# Altium schematic sheet Slave_V2_1.SchDoc(Slave_V2_1)
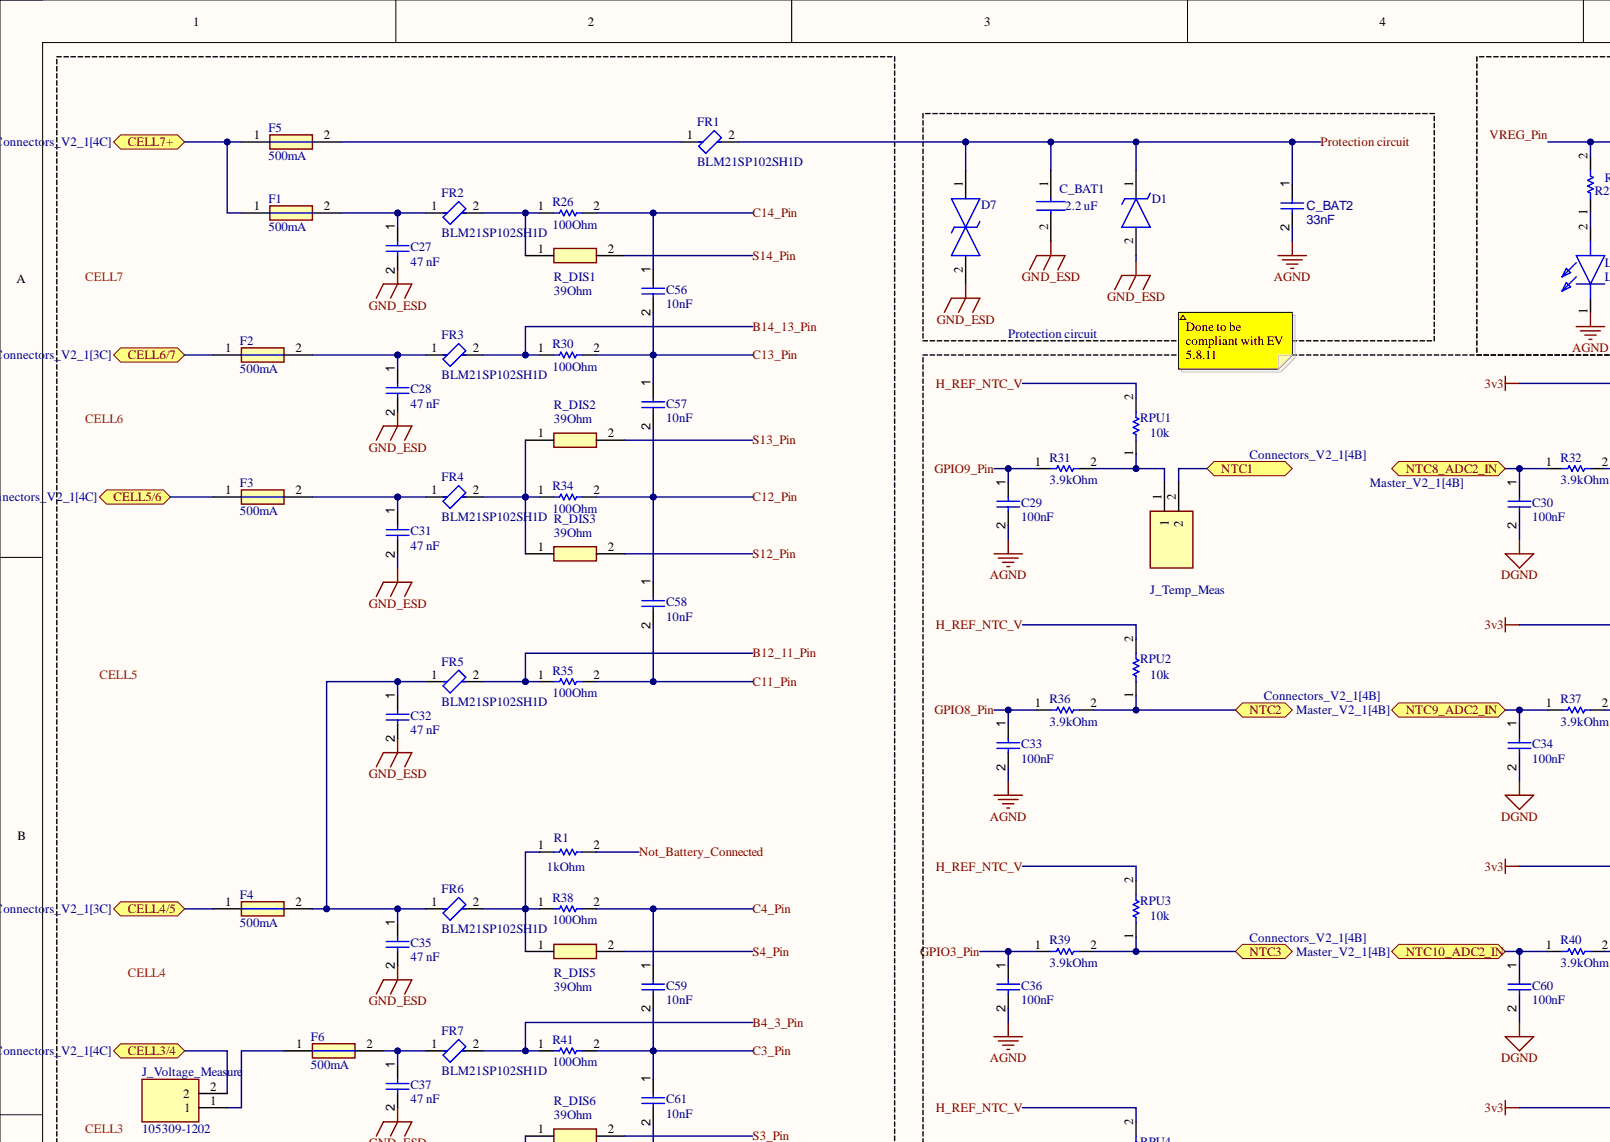
[diagram p0: Slave_V2_1.SchDoc, top left]
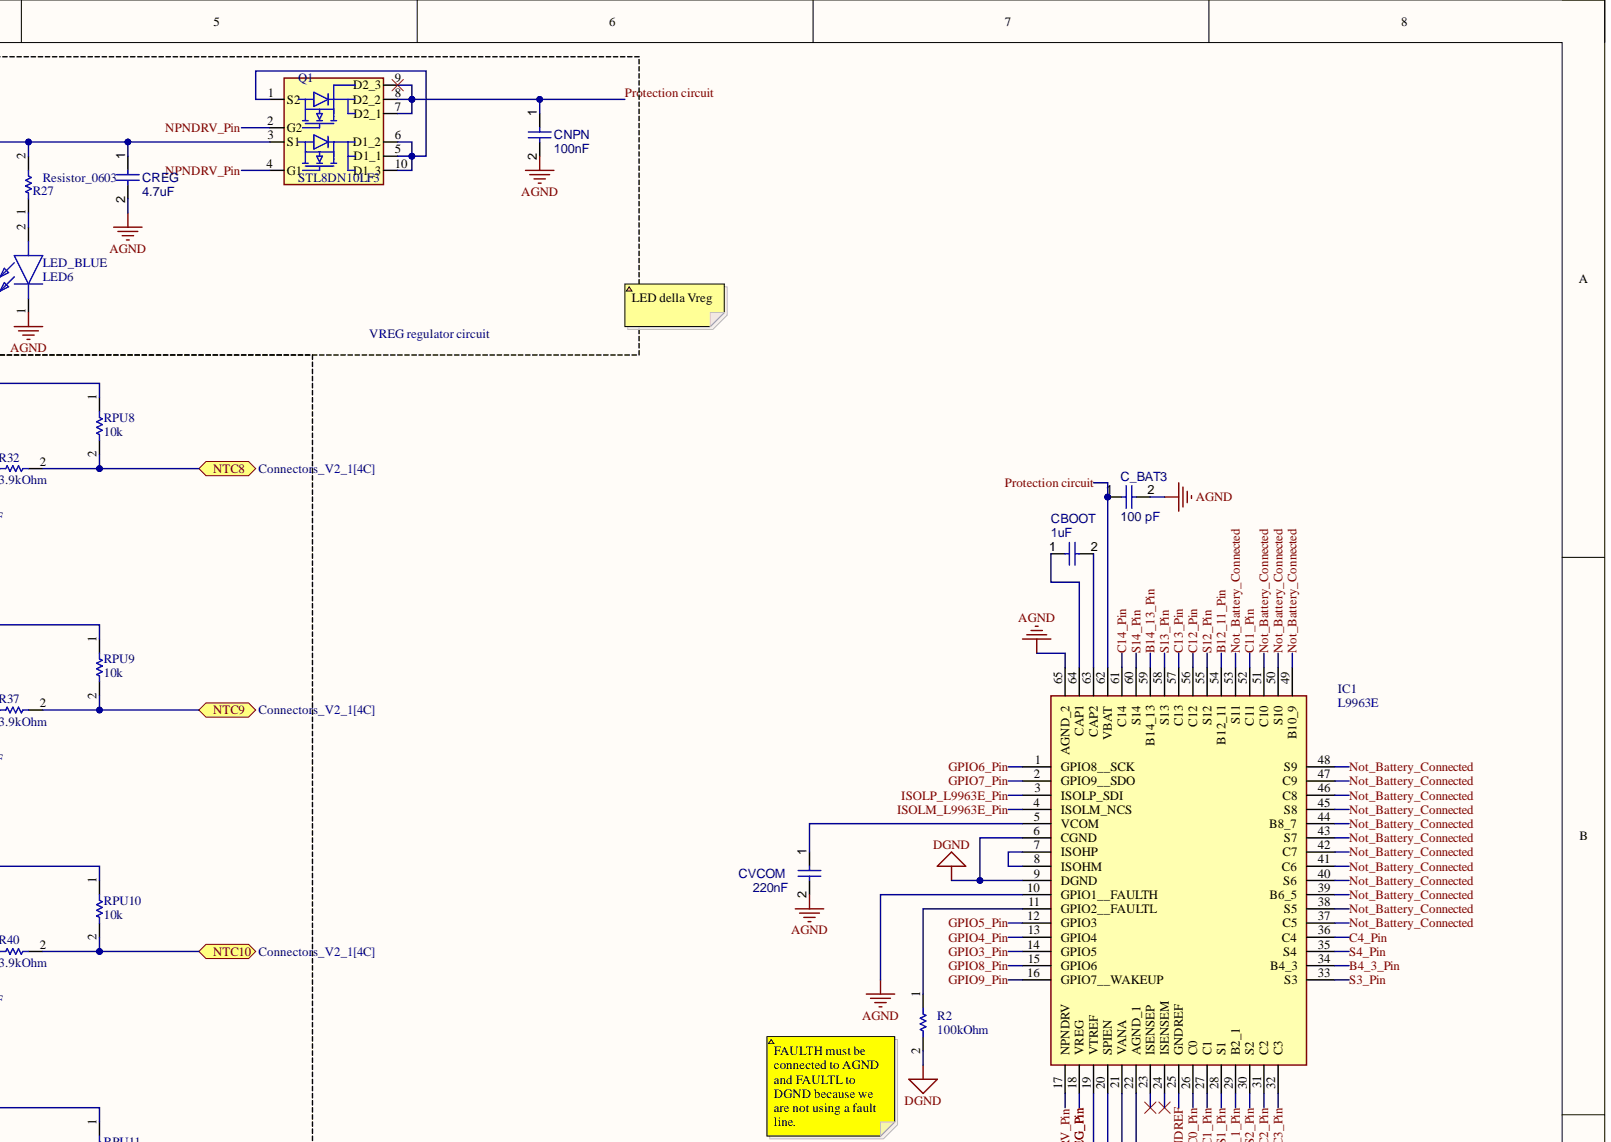
[diagram p1: Slave_V2_1.SchDoc, top right]
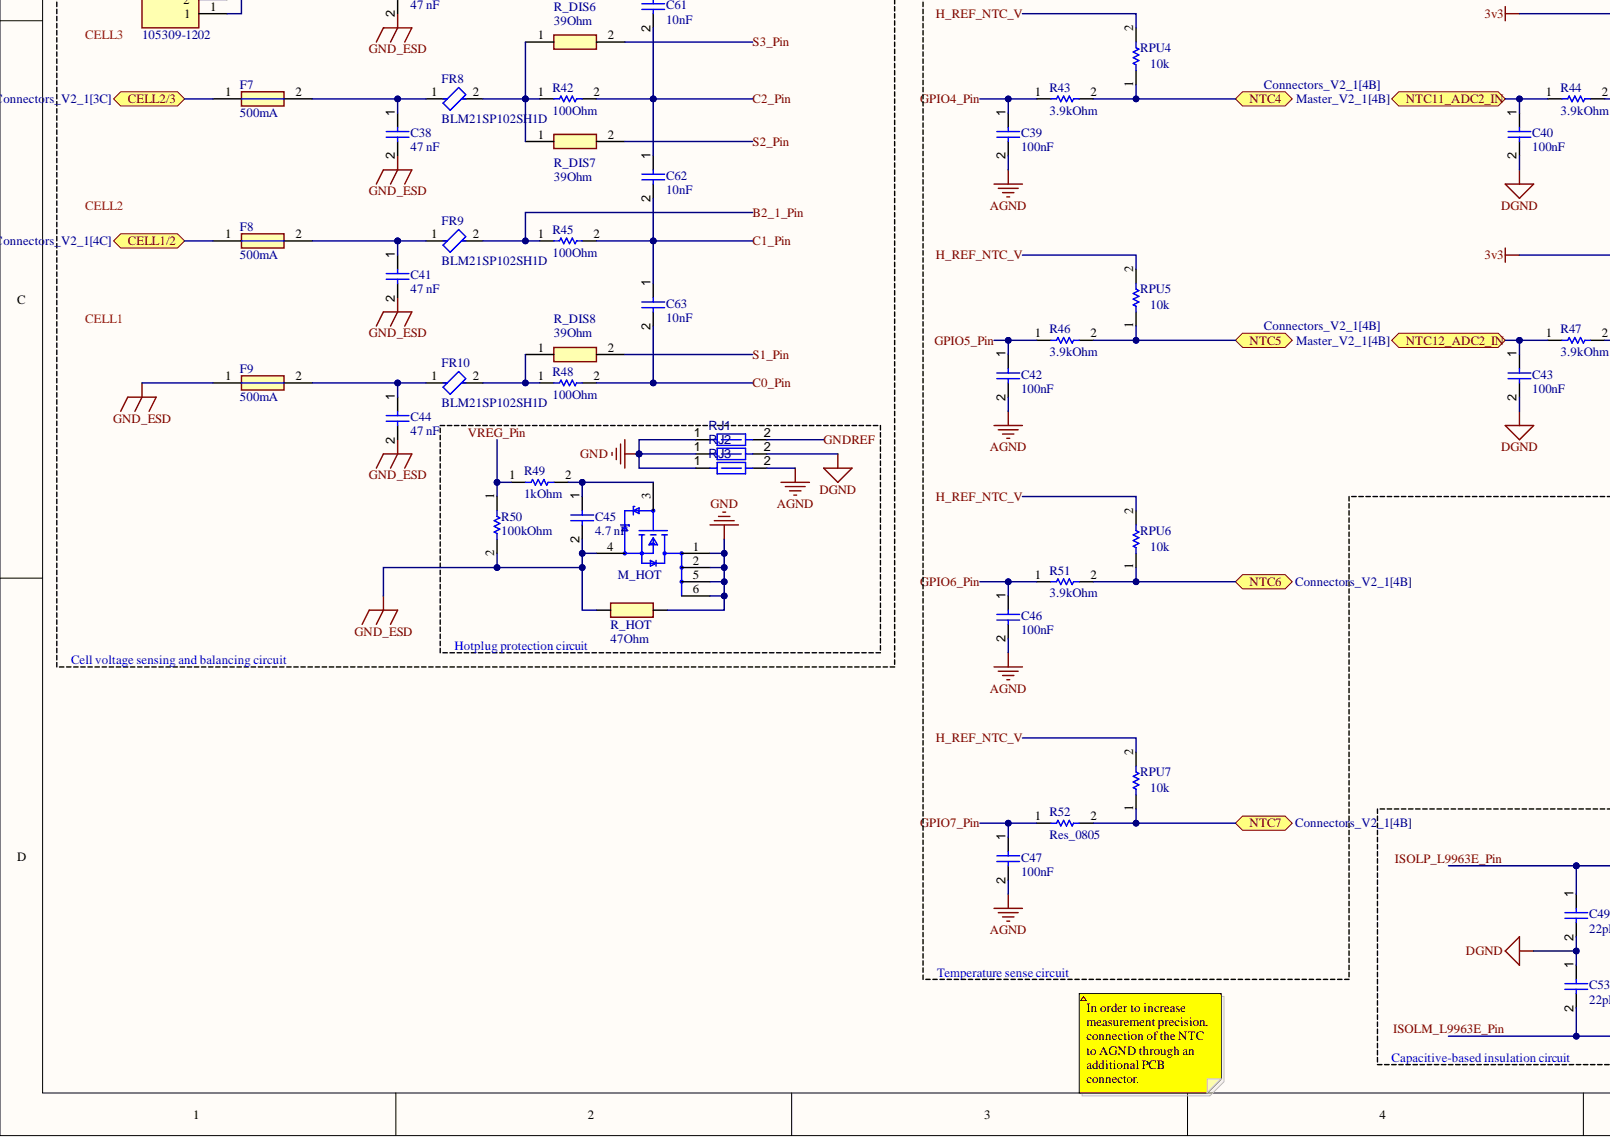
[diagram p2: Slave_V2_1.SchDoc, bottom left]
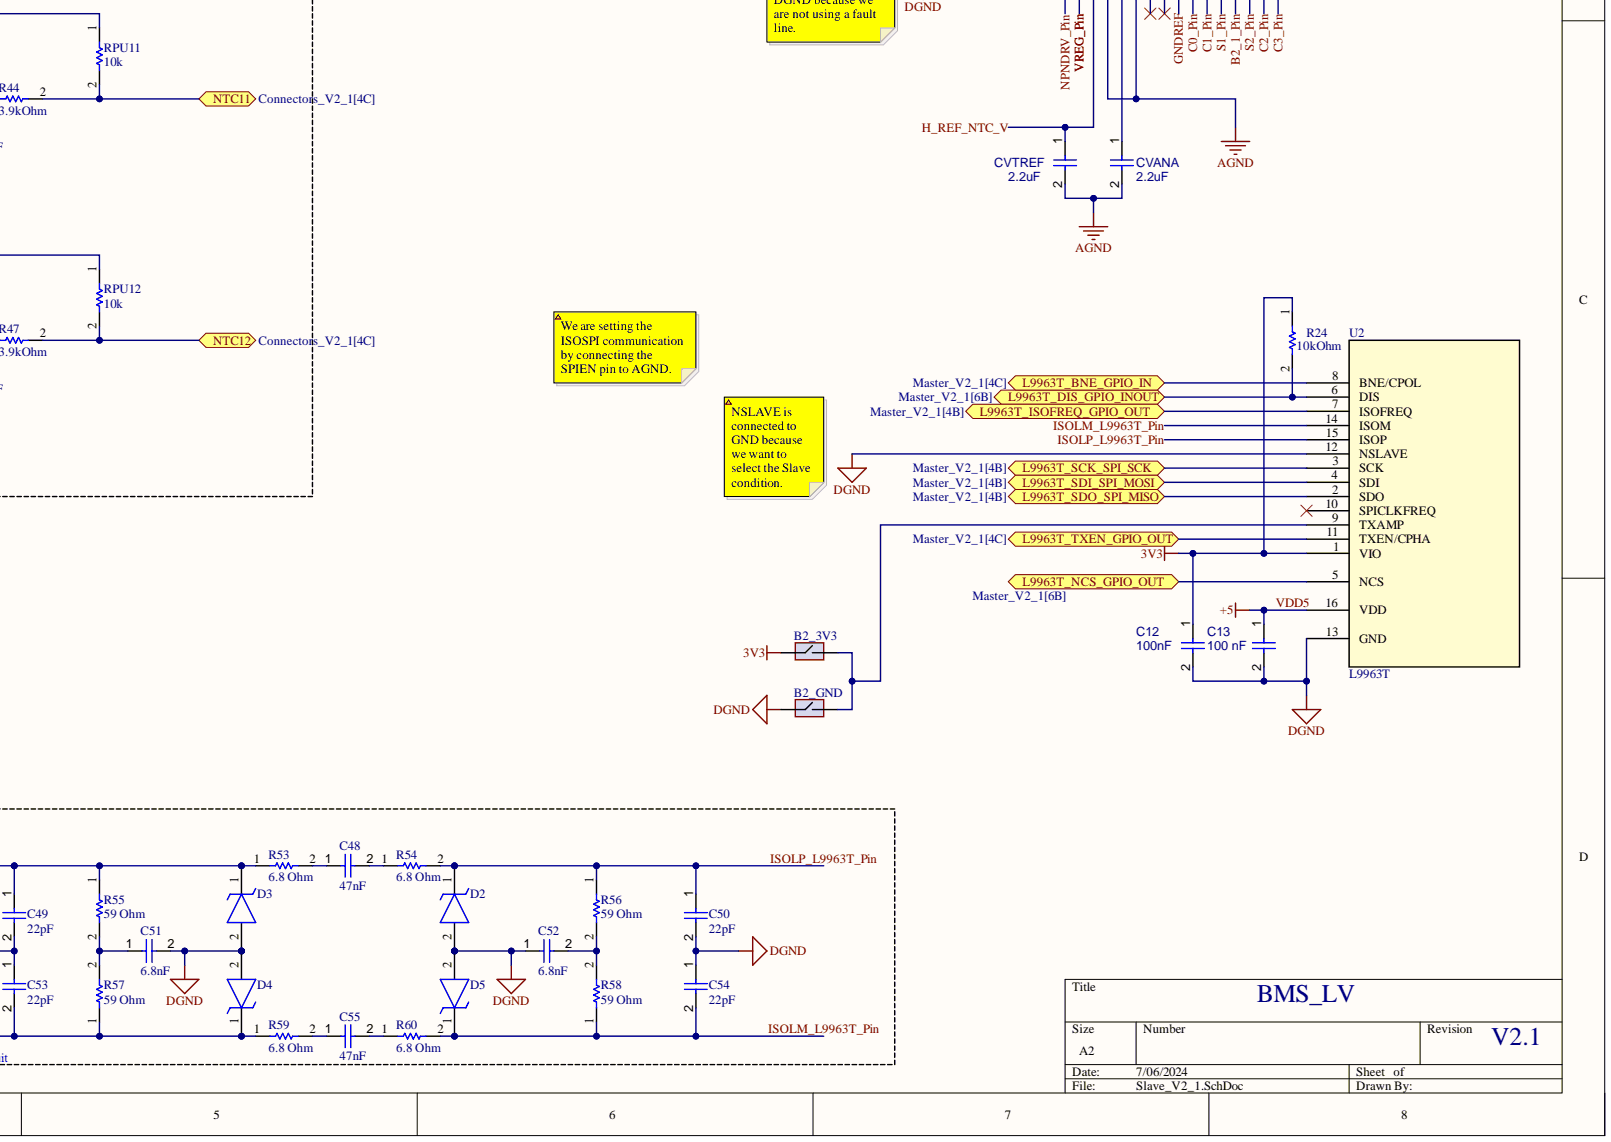
[diagram p3: Slave_V2_1.SchDoc, bottom right]

## Parts
B2_3V3  SolderBridge_0805  (value not drawn)  (no BOM)
B2_GND  SolderBridge_0805  (value not drawn)  (no BOM)
C12  100nF
C13  100 nF
C27  47 nF
C28  47 nF
C29  100nF
C30  100nF
C31  47 nF
C32  47 nF
C33  100nF
C34  100nF
C35  47 nF
C36  100nF
C37  47 nF
C38  47 nF
C39  100nF
C40  100nF
C41  47 nF
C42  100nF
C43  100nF
C44  47 nF
C45  4.7 nF
C46  100nF
C47  100nF
C48  47nF
C49  22pF
C50  22pF
C51  6.8nF
C52  6.8nF
C53  22pF
C54  22pF
C55  47nF
C56  10nF
C57  10nF
C58  10nF
C59  10nF
C60  100nF
C61  10nF
C62  10nF
C63  10nF
C_BAT1  2.2 uF
C_BAT2  33nF
C_BAT3  100 pF
CBOOT  1uF
CNPN  100nF
CREG  4.7uF
CVANA  2.2uF
CVCOM  220nF
CVTREF  2.2uF
D1  SMA6T68AY  (value not drawn)
D2  SZESD8351P2T5G  (value not drawn)
D3  SZESD8351P2T5G  (value not drawn)
D4  SZESD8351P2T5G  (value not drawn)
D5  SZESD8351P2T5G  (value not drawn)
D7  SMAJ30CA-TR  (value not drawn)
F1  500mA
F2  500mA
F3  500mA
F4  500mA
F5  500mA
F6  500mA
F7  500mA
F8  500mA
F9  500mA
FR1  BLM21SP102SH1D
FR2  BLM21SP102SH1D
FR3  BLM21SP102SH1D
FR4  BLM21SP102SH1D
FR5  BLM21SP102SH1D
FR6  BLM21SP102SH1D
FR7  BLM21SP102SH1D
FR8  BLM21SP102SH1D
FR9  BLM21SP102SH1D
FR10  BLM21SP102SH1D
IC1  L9963E
J_Temp_Meas  105309-1202
J_Voltage_Measure  105309-1202
LED6  LED_BLUE
M_HOT  PMN280ENEAX  (value not drawn)
Q1  STL8DN10LF3
R1  1kOhm
R2  100kOhm
R24  10kOhm
R26  100Ohm
R27  Resistor_0603
R30  100Ohm
R31  3.9kOhm
R32  3.9kOhm
R34  100Ohm
R35  100Ohm
R36  3.9kOhm
R37  3.9kOhm
R38  100Ohm
R39  3.9kOhm
R40  3.9kOhm
R41  100Ohm
R42  100Ohm
R43  3.9kOhm
R44  3.9kOhm
R45  100Ohm
R46  3.9kOhm
R47  3.9kOhm
R48  100Ohm
R49  1kOhm
R50  100kOhm
R51  3.9kOhm
R52  Res_0805
R53  6.8 Ohm
R54  6.8 Ohm
R55  59 Ohm
R56  59 Ohm
R57  59 Ohm
R58  59 Ohm
R59  6.8 Ohm
R60  6.8 Ohm
R_DIS1  39Ohm
R_DIS2  39Ohm
R_DIS3  39Ohm
R_DIS5  39Ohm
R_DIS6  39Ohm
R_DIS7  39Ohm
R_DIS8  39Ohm
R_HOT  47Ohm
RJ1  Net Tie 12x8mil  (value not drawn)
RJ2  Net Tie 12x8mil  (value not drawn)
RJ3  Net Tie 12x8mil  (value not drawn)
RPU1  10k
RPU2  10k
RPU3  10k
RPU4  10k
RPU5  10k
RPU6  10k
RPU7  10k
RPU8  10k
RPU9  10k
RPU10  10k
RPU11  10k
RPU12  10k
U2  L9963T

## Ports
CELL1/2, CELL2/3, CELL3/4, CELL4/5, CELL5/6, CELL6/7, CELL7+, L9963T_BNE_GPIO_IN, L9963T_DIS_GPIO_INOUT, L9963T_ISOFREQ_GPIO_OUT, L9963T_NCS_GPIO_OUT, L9963T_SCK_SPI_SCK, L9963T_SDI_SPI_MOSI, L9963T_SDO_SPI_MISO, L9963T_TXEN_GPIO_OUT, NTC1, NTC2, NTC3, NTC4, NTC5, NTC6, NTC7, NTC8, NTC8_ADC2_IN, NTC9, NTC9_ADC2_IN, NTC10, NTC10_ADC2_IN, NTC11, NTC11_ADC2_IN, NTC12, NTC12_ADC2_IN

## Nets
3v3: B2_3V3.1 C12.1 R24.1 RPU8.1 RPU9.1 RPU10.1 RPU11.1 RPU12.1 U2.1(VIO)
AGND: C29.2 C33.2 C36.2 C39.2 C42.2 C46.2 C47.2 C_BAT2.2 C_BAT3.2 CNPN.2 CREG.2 CVANA.2 CVCOM.2 CVTREF.2 IC1.10(GPIO1__FAULTH) IC1.20(SPIEN) IC1.22(AGND_1) IC1.65(AGND_2) LED6.1 RJ3.2
DGND: B2_GND.1 C12.2 C13.2 C30.2 C34.2 C40.2 C43.2 C49.2 C50.2 C51.2 C52.1 C53.1 C54.1 C60.2 D2.2 D3.2 D4.2 D5.2 IC1.6(CGND) IC1.9(DGND) R2.2 RJ2.2 U2.12(NSLAVE) U2.13(GND)
GND: M_HOT.1 M_HOT.2 M_HOT.5 M_HOT.6 R_HOT.2 RJ1.1 RJ2.1 RJ3.1
GND_ESD: C27.2 C28.2 C31.2 C32.2 C35.2 C37.2 C38.2 C41.2 C44.2 C45.2 C_BAT1.2 D1.2 D7.2 F9.1 M_HOT.4 R50.2 R_HOT.1
B2_1_Pin: FR9.2 IC1.29(B2_1) R45.1
B4_3_Pin: FR7.2 IC1.34(B4_3) R41.1
B12_11_Pin: FR5.2 IC1.54(B12_11) R35.1
B14_13_Pin: FR3.2 IC1.59(B14_13) R30.1
C0_Pin: C63.2 IC1.26(C0) R48.2
C1_Pin: C62.2 C63.1 IC1.27(C1) R45.2
C2_Pin: C61.2 C62.1 IC1.31(C2) R42.2
C3_Pin: C59.2 C61.1 IC1.32(C3) R41.2
C4_Pin: C59.1 IC1.36(C4) R38.2
C11_Pin: C58.2 IC1.52(C11) R35.2
C12_Pin: C57.2 C58.1 IC1.56(C12) R34.2
C13_Pin: C56.2 C57.1 IC1.57(C13) R30.2
C14_Pin: C56.1 IC1.61(C14) R26.2
CELL1/2: F8.1 ; port CELL1/2
CELL2/3: F7.1 ; port CELL2/3
CELL3/4: J_Voltage_Measure.2 ; port CELL3/4
CELL4/5: F4.1 ; port CELL4/5
CELL5/6: F3.1 ; port CELL5/6
CELL6/7: F2.1 ; port CELL6/7
CELL7+: F1.1 F5.1 ; port CELL7+
GNDREF: IC1.25(GNDREF) RJ1.2
GPIO3_Pin: C36.1 IC1.14(GPIO5) R39.1
GPIO4_Pin: C39.1 IC1.13(GPIO4) R43.1
GPIO5_Pin: C42.1 IC1.12(GPIO3) R46.1
GPIO6_Pin: C46.1 IC1.1(GPIO8__SCK) R51.1
GPIO7_Pin: C47.1 IC1.2(GPIO9__SDO) R52.1
GPIO8_Pin: C33.1 IC1.15(GPIO6) R36.1
GPIO9_Pin: C29.1 IC1.16(GPIO7__WAKEUP) R31.1
H_REF_NTC_V: CVTREF.1 IC1.19(VTREF) RPU1.2 RPU2.2 RPU3.2 RPU4.2 RPU5.2 RPU6.2 RPU7.2
ISOLM_L9963E_Pin: C53.2 D4.1 IC1.4(ISOLM_NCS) R57.1 R59.1
ISOLM_L9963T_Pin: C54.2 D5.1 R58.1 R60.2 U2.14(ISOM)
ISOLP_L9963E_Pin: C49.1 D3.1 IC1.3(ISOLP_SDI) R53.1 R55.1
ISOLP_L9963T_Pin: C50.1 D2.1 R54.2 R56.1 U2.15(ISOP)
L9963T_BNE_GPIO_IN: U2.8(BNE/CPOL) ; port L9963T_BNE_GPIO_IN
L9963T_DIS_GPIO_INOUT: R24.2 U2.6(DIS) ; port L9963T_DIS_GPIO_INOUT
L9963T_ISOFREQ_GPIO_OUT: U2.7(ISOFREQ) ; port L9963T_ISOFREQ_GPIO_OUT
L9963T_NCS_GPIO_OUT: U2.5(NCS) ; port L9963T_NCS_GPIO_OUT
L9963T_SCK_SPI_SCK: U2.3(SCK) ; port L9963T_SCK_SPI_SCK
L9963T_SDI_SPI_MOSI: U2.4(SDI) ; port L9963T_SDI_SPI_MOSI
L9963T_SDO_SPI_MISO: U2.2(SDO) ; port L9963T_SDO_SPI_MISO
L9963T_TXEN_GPIO_OUT: U2.11(TXEN/CPHA) ; port L9963T_TXEN_GPIO_OUT
Not_Battery_Connected: IC1.37(C5) IC1.38(S5) IC1.39(B6_5) IC1.40(S6) IC1.41(C6) IC1.42(C7) IC1.43(S7) IC1.44(B8_7) IC1.45(S8) IC1.46(C8) IC1.47(C9) IC1.48(S9) IC1.49(B10_9) IC1.50(S10) IC1.51(C10) IC1.53(S11) R1.2
NPNDRV_Pin: IC1.17(NPNDRV) Q1.2(G2) Q1.4(G1)
NTC1: J_Temp_Meas.2 ; port NTC1
NTC2: R36.2 RPU2.1 ; port NTC2
NTC3: R39.2 RPU3.1 ; port NTC3
NTC4: R43.2 RPU4.1 ; port NTC4
NTC5: R46.2 RPU5.1 ; port NTC5
NTC6: R51.2 RPU6.1 ; port NTC6
NTC7: R52.2 RPU7.1 ; port NTC7
NTC8: R32.2 RPU8.2 ; port NTC8
NTC8_ADC2_IN: C30.1 R32.1 ; port NTC8_ADC2_IN
NTC9: R37.2 RPU9.2 ; port NTC9
NTC9_ADC2_IN: C34.1 R37.1 ; port NTC9_ADC2_IN
NTC10: R40.2 RPU10.2 ; port NTC10
NTC10_ADC2_IN: C60.1 R40.1 ; port NTC10_ADC2_IN
NTC11: R44.2 RPU11.2 ; port NTC11
NTC11_ADC2_IN: C40.1 R44.1 ; port NTC11_ADC2_IN
NTC12: R47.2 RPU12.2 ; port NTC12
NTC12_ADC2_IN: C43.1 R47.1 ; port NTC12_ADC2_IN
Protection circuit: C_BAT1.1 C_BAT2.1 C_BAT3.1 CNPN.1 D1.1 D7.1 FR1.2 IC1.62(VBAT) Q1.7(D2_1) Q1.8(D2_2) Q1.9(D2_3)
S1_Pin: IC1.28(S1) R_DIS8.2
S2_Pin: IC1.30(S2) R_DIS7.2
S3_Pin: IC1.33(S3) R_DIS6.2
S4_Pin: IC1.35(S4) R_DIS5.2
S12_Pin: IC1.55(S12) R_DIS3.2
S13_Pin: IC1.58(S13) R_DIS2.2
S14_Pin: IC1.60(S14) R_DIS1.2
VDD5: C13.1 U2.16(VDD)
VREG_Pin: CREG.1 IC1.18(VREG) Q1.3(S1) R27.2 R49.1 R50.1
NetB2_3V3_2: B2_3V3.2 B2_GND.2 U2.9(TXAMP)
NetC27_1: C27.1 F1.2 FR2.1
NetC28_1: C28.1 F2.2 FR3.1
NetC31_1: C31.1 F3.2 FR4.1
NetC32_1: C32.1 C35.1 F4.2 FR5.1 FR6.1
NetC37_1: C37.1 F6.2 FR7.1
NetC38_1: C38.1 F7.2 FR8.1
NetC41_1: C41.1 F8.2 FR9.1
NetC44_1: C44.1 F9.2 FR10.1
NetC45_1: C45.1 M_HOT.3 R49.2
NetC48_1: C48.1 R53.2
NetC48_2: C48.2 R54.1
NetC51_1: C51.1 R55.2 R57.2
NetC52_2: C52.2 R56.2 R58.2
NetC55_1: C55.1 R59.2
NetC55_2: C55.2 R60.1
NetCBOOT_1: CBOOT.1 IC1.64(CAP1)
NetCBOOT_2: CBOOT.2 IC1.63(CAP2)
NetCVANA_1: CVANA.1 IC1.21(VANA)
NetCVCOM_1: CVCOM.1 IC1.5(VCOM)
NetF5_2: F5.2 FR1.1
NetF6_1: F6.1 J_Voltage_Measure.1
NetFR2_2: FR2.2 R26.1 R_DIS1.1
NetFR4_2: FR4.2 R34.1 R_DIS2.1 R_DIS3.1
NetFR6_2: FR6.2 R1.1 R38.1 R_DIS5.1
NetFR8_2: FR8.2 R42.1 R_DIS6.1 R_DIS7.1
NetFR10_2: FR10.2 R48.1 R_DIS8.1
NetIC1_7: IC1.7(ISOHP) IC1.8(ISOHM)
NetIC1_11: IC1.11(GPIO2__FAULTL) R2.1
NetJ_Temp_Meas_1: J_Temp_Meas.1 R31.2 RPU1.1
NetLED6_2: LED6.2 R27.1
NetQ1_1: Q1.1(S2) Q1.5(D1_1) Q1.6(D1_2) Q1.10(D1_3)

Not connected: IC1 23(ISENSEP) 24(ISENSEM); U2 10(SPICLKFREQ)

## Library data of the parts
B2_3V3 B2_GND = CMP-00006-5: 0805 Solder Bridge; Library Name: EMEA- Squadra Corse PoliTO-  Politecnico di Torino
C12 C13 = CMP-001-00085-2: VJ0805Y104MXATW1BC; Tech: SMD; Component Kind: Standard; ComponentLink1Description: Website; Package: 0805; Library Name: EMEA- Squadra Corse PoliTO-  Politecnico di Torino
C27 C28 C31 C32 C35 C37 C38 C41 C44 C48 C55 = CMP-00009-1: VJ0805Y473KXBAT; Component Kind: Standard; Library Name: EMEA- Squadra Corse PoliTO-  Politecnico di Torino
C29 C30 C33 C34 C36 C39 C40 C42 C43 C46 C47 C60 = CMP-00009-1: VJ0805Y104KBABE31; Component Kind: Standard; Library Name: EMEA- Squadra Corse PoliTO-  Politecnico di Torino
C45 = CMP-00009-1: VJ0805Y472JXJCW1BC; Component Kind: Standard; Library Name: EMEA- Squadra Corse PoliTO-  Politecnico di Torino
C49 C50 C53 C54 = CMP-00009-1: VJ0805A220JXBAC; Component Kind: Standard; Library Name: EMEA- Squadra Corse PoliTO-  Politecnico di Torino
C51 C52 = CMP-00009-1: VJ0805Y682KXAAC; Component Kind: Standard; Library Name: EMEA- Squadra Corse PoliTO-  Politecnico di Torino
C56-C59 C61-C63 = CMP-00009-1: VJ0805H103KXBBC31; Component Kind: Standard; Library Name: EMEA- Squadra Corse PoliTO-  Politecnico di Torino
C_BAT1 = CMP-002-000022-1: C3225X7R2A225K230AM; Manufacturer_Name: TDK; Arrow Part Number: C3225X7R2A225K230AM; Arrow Price/Stock: https://www.arrow.com/en/products/c3225x7r2a225k230am/tdk?region=nac; Component Kind: Standard; Datasheet Link: https://product.tdk.com/system/files/dam/doc/product/capacitor/ceramic/mlcc/catalog/mlcc_commercial_openmode_en.pdf; Design Item ID: C3225X7R2A225K230AM; Height: 2.8mm; Manufacturer_Part_Number: C3225X7R2A225K230AM; Mouser Part Number: 810-C3225X7R2A225KT5; Mouser Price/Stock: https://www.mouser.co.uk/ProductDetail/TDK/C3225X7R2A225K230AM?qs=LcTL%2F5vFEzFqvsZK9aVShQ%3D%3D; Source: SamacSys.SchLib; Library Name: EMEA- Squadra Corse PoliTO-  Politecnico di Torino
C_BAT2 = CMP-001-00085-2: VJ0805Y332KXBMC; Tech: SMD; Component Kind: Standard; ComponentLink1Description: Website; Package: 0805; Library Name: EMEA- Squadra Corse PoliTO-  Politecnico di Torino
C_BAT3 = CMP-001-00085-2: VJ0805A101KXBAC; Tech: SMD; Component Kind: Standard; ComponentLink1Description: Website; Package: 0805; Library Name: EMEA- Squadra Corse PoliTO-  Politecnico di Torino
CBOOT = CMP-001-00085-2: VJ0805Y105KXXTW1BC; Tech: SMD; Component Kind: Standard; ComponentLink1Description: Website; Package: 0805; Library Name: EMEA- Squadra Corse PoliTO-  Politecnico di Torino
CNPN = CMP-001-00085-2: VJ0805Y104KBABE31; Tech: SMD; Component Kind: Standard; ComponentLink1Description: Website; Package: 0805; Library Name: EMEA- Squadra Corse PoliTO-  Politecnico di Torino
CREG = CMP-001-00085-2: GCJ21BR71C475KA01L; Tech: SMD; Component Kind: Standard; ComponentLink1Description: Website; Package: 0805; Library Name: EMEA- Squadra Corse PoliTO-  Politecnico di Torino
CVANA CVTREF = CMP-001-00085-2: VJ0805Y225KXJTW1BC; Tech: SMD; Component Kind: Standard; ComponentLink1Description: Website; Package: 0805; Library Name: EMEA- Squadra Corse PoliTO-  Politecnico di Torino
CVCOM = CMP-001-00085-2: VJ0805Y224KXJMT; Tech: SMD; Component Kind: Standard; ComponentLink1Description: Website; Package: 0805; Library Name: EMEA- Squadra Corse PoliTO-  Politecnico di Torino
D1 = CMP-004-000001-1: TVS Diode (Uni-directional); Manufacturer_Name: STMicroelectronics; Arrow Part Number: SMA6T68AY; Arrow Price/Stock: https://www.arrow.com/en/products/sma6t68ay/stmicroelectronics?region=nac; Component Kind: Standard; Datasheet Link: https://www.mouser.de/datasheet/2/389/cd00283518-1797095.pdf; Design Item ID: SMA6T68AY; Height: 2.65mm; Manufacturer_Part_Number: SMA6T68AY; Mouser Part Number: 511-SMA6T68AY; Mouser Price/Stock: https://www.mouser.co.uk/ProductDetail/STMicroelectronics/SMA6T68AY?qs=mxm%2FV7p14IMJ951bsWTQng%3D%3D; Source: SamacSys.SchLib; Library Name: EMEA- Squadra Corse PoliTO-  Politecnico di Torino
D2-D5 = CMP-004-000002-1: ESD Suppressors / TVS Diodes LOW CAP SNAPBACK ESD PROT; Manufacturer_Name: onsemi; Arrow Part Number: SZESD8351P2T5G; Arrow Price/Stock: https://www.arrow.com/en/products/szesd8351p2t5g/on-semiconductor?region=nac; Component Kind: Standard; Datasheet Link: https://www.onsemi.com/pub/Collateral/ESD8351P2-D.PDF; Design Item ID: SZESD8351P2T5G; Height: 0.4mm; Manufacturer_Part_Number: SZESD8351P2T5G; Mouser Part Number: 863-SZESD8351P2T5G; Mouser Price/Stock: https://www.mouser.co.uk/ProductDetail/onsemi/SZESD8351P2T5G?qs=dbuNSGnowt0hk7yUFmffJA%3D%3D; Source: SamacSys.SchLib; Library Name: EMEA- Squadra Corse PoliTO-  Politecnico di Torino
D7 = CMP-004-000005-1: TVS Diode Bi-Directional SMAJ30CA-TR 64.3V; Manufacturer_Name: STMicroelectronics; Arrow Part Number: SMAJ30CA-TR; Arrow Price/Stock: https://www.arrow.com/en/products/smaj30ca-tr/stmicroelectronics?region=nac; Component Kind: Standard; Datasheet Link: https://www.st.com/resource/en/datasheet/smaj10ca.pdf; Design Item ID: SMAJ30CA-TR; Height: 2.65mm; Manufacturer_Part_Number: SMAJ30CA-TR; Mouser Part Number: 511-SMAJ30CA-TR; Mouser Price/Stock: https://www.mouser.co.uk/ProductDetail/STMicroelectronics/SMAJ30CA-TR?qs=0TJjK1waviDY0EkLaKH12g%3D%3D; Source: SamacSys.SchLib; Library Name: EMEA- Squadra Corse PoliTO-  Politecnico di Torino
F1-F9 = CMP-005-000004-1: MFU1206FF00500P100; Manufacturer_Name: Vishay; Arrow Part Number: MFU1206FF00500P100; Arrow Price/Stock: https://www.arrow.com/en/products/mfu1206ff00500p100/vishay?region=nac; Component Kind: Standard; Datasheet Link: http://www.vishay.com/docs/28747/mfuserie.pdf; Design Item ID: MFU1206FF00500P100; Height: 0.65mm; Manufacturer_Part_Number: MFU1206FF00500P100; Mouser Part Number: 594-MFU1206FF00500P1; Mouser Price/Stock: https://www.mouser.co.uk/ProductDetail/Vishay-Beyschlag/MFU1206FF00500P100?qs=oI046glRurs9%2FzbQ%2Fl2sBw%3D%3D; Source: SamacSys.SchLib; Library Name: EMEA- Squadra Corse PoliTO-  Politecnico di Torino
FR1-FR10 = CMP-00011-1: Ferrite 1kOhm@100 Mhz, 1,6A, 0805; Component Kind: Standard; Library Name: EMEA- Squadra Corse PoliTO-  Politecnico di Torino
IC1 = CMP-003-000005-1: Battery Management Automotive chip for battery management applications daisy chain up to 31 devices; Manufacturer_Name: STMicroelectronics; Arrow Part Number: L9963E; Arrow Price/Stock: https://www.arrow.com/en/products/l9963e/stmicroelectronics?region=nac; Component Kind: Standard; Datasheet Link: https://www.st.com/resource/en/datasheet/l9963e.pdf; Design Item ID: L9963E; Height: 1.2mm; Manufacturer_Part_Number: L9963E; Mouser Part Number: 511-L9963E; Mouser Price/Stock: https://www.mouser.co.uk/ProductDetail/STMicroelectronics/L9963E?qs=CiayqK2gdcLHuPZfXyysMw%3D%3D; Source: SamacSys.SchLib; Library Name: EMEA- Squadra Corse PoliTO-  Politecnico di Torino
J_Temp_Meas J_Voltage_Measure = CMP-032-000006-1: Molex Nano-Fit 105309; Component Kind: Standard; Datasheet Link: https://www.molex.com/pdm_docs/sd/1053091202_sd.pdf; Design Item ID: 105309-1202; Height: 10.51mm; Manufacturer_Name: Molex; Manufacturer_Part_Number: 105309-1202; Mouser Part Number: 538-105309-1202; Mouser Price/Stock: https://www.mouser.co.uk/ProductDetail/Molex/105309-1202?qs=PqFvFmEiOr43z2VKs7gb%2Fg%3D%3D; Source: SamacSys.SchLib; Library Name: EMEA- Squadra Corse PoliTO-  Politecnico di Torino
LED6 = CMP-031-000016-1: APT2012LVBC_D; Manufacturer_Name: Kingbright; Manufacturer_Part_Number: APT2012LVBC/D; Arrow Part Number: APT2012LVBC/D; Arrow Price/Stock: https://www.arrow.com/en/products/apt2012lvbcd/kingbright; Component Kind: Standard; Datasheet Link: https://componentsearchengine.com/Datasheets/1/APT2012LVBC_D.pdf; Design Item ID: APT2012LVBC_D; Height: 0.85mm; Mouser Part Number: 604-APT2012LVBCD; Mouser Price/Stock: https://www.mouser.co.uk/ProductDetail/Kingbright/APT2012LVBC-D?qs=6oMev5NRZMGErJYT6KZLXg%3D%3D; Source: SamacSys.SchLib; Library Name: EMEA- Squadra Corse PoliTO-  Politecnico di Torino
M_HOT = CMP-14409-000010-1: PMN280ENEA/SOT457/SC-74; Manufacturer: Nexperia; Automotive Grade: Grade 0; Continuous Drain Current: 1.2A; Depletion Mode: False; Drain To Source Breakdown Voltage: 100V; Drain To Source Resistance: 285mΩ; Gate Charge At Vgs: 4.5nC @ 10V; Gate To Source Voltage: 20V; Input Capacitace At Vds: 190pF @ 50V; Max Forward Diode Voltage: 1.2V; Max Junction Temp: +175°C; Number Of Channels: 1; Power Dissipation: 667mW; Pulse Drain Current: 5A; Reverse Recovery Charge: 11nC; Reverse Recovery Time: 20ns; Rthja Max: 225K/W; Standoff Height: 0.013mm; Threshold Vgs Max: 2.7V; Threshold Vgs Min: 1.3V; Transconductance: 5.2S; Turn Off Delay Time: 10ns; Turn On Delay Time: 3ns; Typ Forward Diode Voltage: 0.8V; Ambient Temperature Range High: +175°C; Ambient Temperature Range Low: -55°C; Automotive: Yes; Category: Trans; Component Kind: Standard; ComponentLink2Description: Datasheet; ComponentLink2URL: https://assets.nexperia.com/documents/data-sheet/PMN280ENEA.pdf; Device Class L1: Discrete Semiconductors; Device Class L2: Transistors; Device Class L3: MOSFETs; Digikey Description: PMN280ENEA/SOT457/SC-74; Drain To Source Voltage: 100V; Footprint Url: https://assets.nexperia.com/documents/outline-drawing/SOT457.pdf; Height: 1.1mm; Ipc Land Pattern Name: SOT95P275X100-6; Lead Free: Yes; Library Name: Altium Content Vault; Manufacturer Part Number: PMN280ENEAX; Number Of N Channels: 1; Octopart: https://octopart.com/pmn280eneax-nexperia-97431579; Package: SOT457; RoHS: TRUE; Temperature: -55°C to +175°C; Temperature Range High: +175°C; Temperature Range Low: -55°C
Q1 = CMP-008-000002-2: MOSFET (N-Channel); Manufacturer_Name: STMicroelectronics; Arrow Part Number: STL8DN10LF3; Arrow Price/Stock: https://www.arrow.com/en/products/stl8dn10lf3/stmicroelectronics; Component Kind: Standard; Datasheet Link: https://www.mouser.de/datasheet/2/389/dm00051677-1797639.pdf; Design Item ID: STL8DN10LF3; Height: 1mm; Manufacturer_Part_Number: STL8DN10LF3; Mouser Part Number: 511-STL8DN10LF3; Mouser Price/Stock: https://www.mouser.co.uk/ProductDetail/STMicroelectronics/STL8DN10LF3/?qs=gNDSiZmRJS8JluwScOQ3vQ%3D%3D; Source: SamacSys.SchLib; Library Name: EMEA- Squadra Corse PoliTO-  Politecnico di Torino
R1 = CMP-009-00181-1: CRCW12061K00FKEAHP; Tech: SMD; Component Kind: Standard; Package: 1206; Library Name: EMEA- Squadra Corse PoliTO-  Politecnico di Torino
R2 = CMP-009-00179-4: MCT06030C1003FPW00; Tech: SMD; Component Kind: Standard; ComponentLink1Description: Website; Package: 0603; Library Name: EMEA- Squadra Corse PoliTO-  Politecnico di Torino
R24 = CMP-009-00179-4: CRCW060310K0FKEAHP; Tech: SMD; Component Kind: Standard; ComponentLink1Description: Website; Package: 0603; Library Name: EMEA- Squadra Corse PoliTO-  Politecnico di Torino
R26 R30 R34 R35 R38 R41 R42 R45 R48 = CMP-00012-1: CRCW0805100RFKEAC; Component Kind: Standard; Library Name: EMEA- Squadra Corse PoliTO-  Politecnico di Torino
R27 = CMP-009-00179-4: CRCW0603300RFKEA; Tech: SMD; Component Kind: Standard; ComponentLink1Description: Website; Package: 0603; Library Name: EMEA- Squadra Corse PoliTO-  Politecnico di Torino
R31 R32 R36 R37 R39 R40 R43 R44 R46 R47 R51 = CMP-00012-1: CRCW08053K90FKEAHP; Component Kind: Standard; Library Name: EMEA- Squadra Corse PoliTO-  Politecnico di Torino
R49 = CMP-00012-1: CRCW06031K00FKEAHP; Component Kind: Standard; Library Name: EMEA- Squadra Corse PoliTO-  Politecnico di Torino
R50 = CMP-00012-1: MCT06030C1003FPW00; Component Kind: Standard; Library Name: EMEA- Squadra Corse PoliTO-  Politecnico di Torino
R52 = CMP-00012-1: General Resistor 0805; Component Kind: Standard; Library Name: EMEA- Squadra Corse PoliTO-  Politecnico di Torino
R53 R54 R59 R60 = CMP-00010-1: CRCW06036R80FNEA; Component Kind: Standard; Library Name: EMEA- Squadra Corse PoliTO-  Politecnico di Torino
R55-R58 = CMP-00010-1: RR0816Q-59R0-D-75R; Component Kind: Standard; Library Name: EMEA- Squadra Corse PoliTO-  Politecnico di Torino
R_DIS1-R_DIS3 R_DIS5-R_DIS8 = CMP-007-000002-1: Res Thick Film 2512 39 Ohm 1% 1.5W +/-100ppm/C Pad SMD Automotive T/R; Manufacturer_Name: Vishay; Manufacturer_Part_Number: CRCW251239R0FKEGHP; Arrow Part Number: CRCW251239R0FKEGHP; Arrow Price/Stock: https://www.arrow.com/en/products/crcw251239r0fkeghp/vishay; Component Kind: Standard; Datasheet Link: http://www.vishay.com/docs/20043/crcwhpe3.pdf; Design Item ID: CRCW251239R0FKEGHP; Height: 0.7mm; Source: SamacSys.SchLib; Library Name: EMEA- Squadra Corse PoliTO-  Politecnico di Torino
R_HOT = CMP-009-00182-1: CRCW251247R0FKEGHP; Component Kind: Standard; Library Name: EMEA- Squadra Corse PoliTO-  Politecnico di Torino
RJ1-RJ3 = CMP-009-00121-7: Component Kind: Net Tie; Description: Net Tie 12x8mil; Max Operating Temperature: 155°C; Min Operating Temperature: -55°C; Pins: 2; Library Name: EMEA- Squadra Corse PoliTO-  Politecnico di Torino
RPU1-RPU12 = CMP-009-00181-1: CRCW120610K0FKEA; Tech: SMD; Component Kind: Standard; Package: 1206; Library Name: EMEA- Squadra Corse PoliTO-  Politecnico di Torino
U2 = CMP-002-000023-1: No Description Available; Mfr_Name: STMicroelectronics; Component Kind: Standard; Design Item ID: L9963T; Manufacturer_Part_Number: L9963T; RefDes: RefDes; Source: L9963T.SchLib; Type: DEV; Copyright: Copyright (C) 2022 Ultra Librarian. All rights reserved.; Library Name: EMEA- Squadra Corse PoliTO-  Politecnico di Torino
